# Altium schematic sheet 11_MCU.SchDoc(11_MCU)
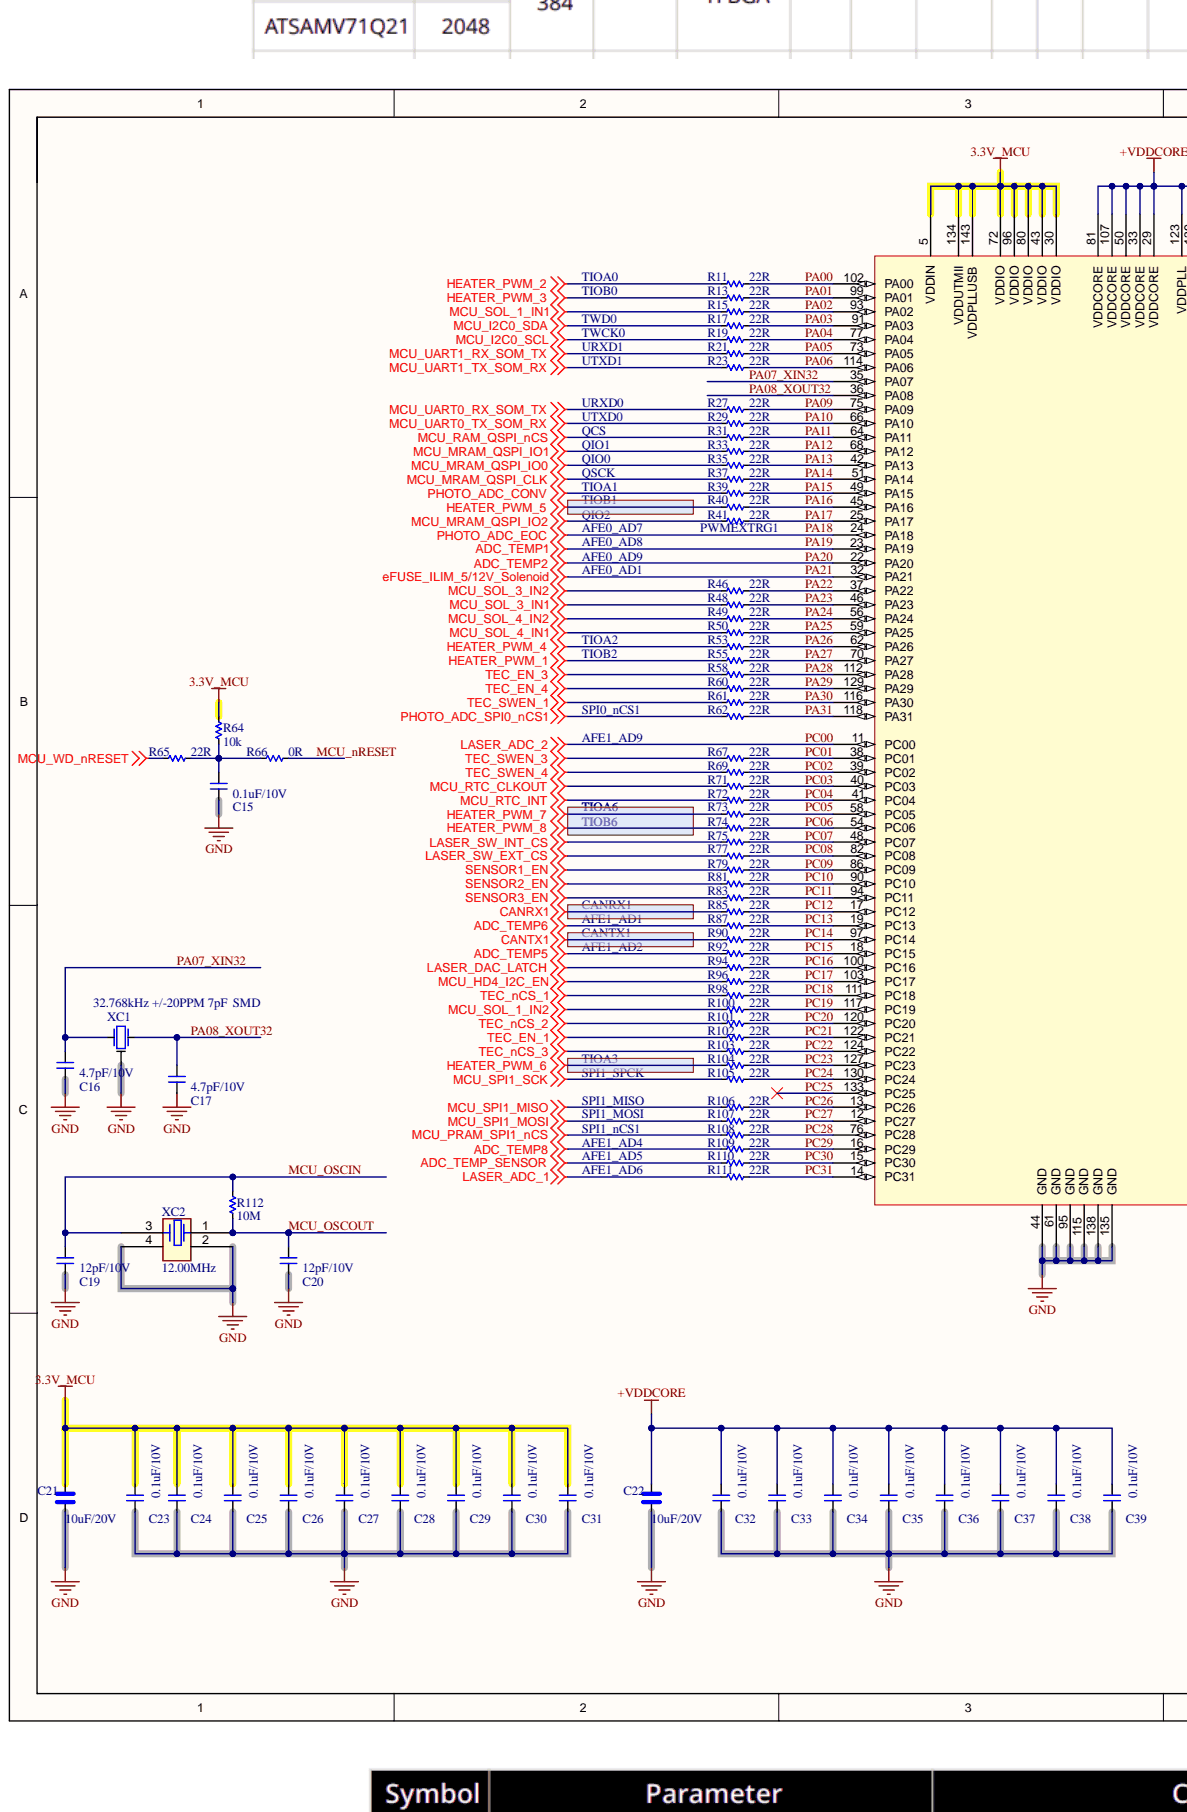
[diagram p0: 11_MCU.SchDoc, left]
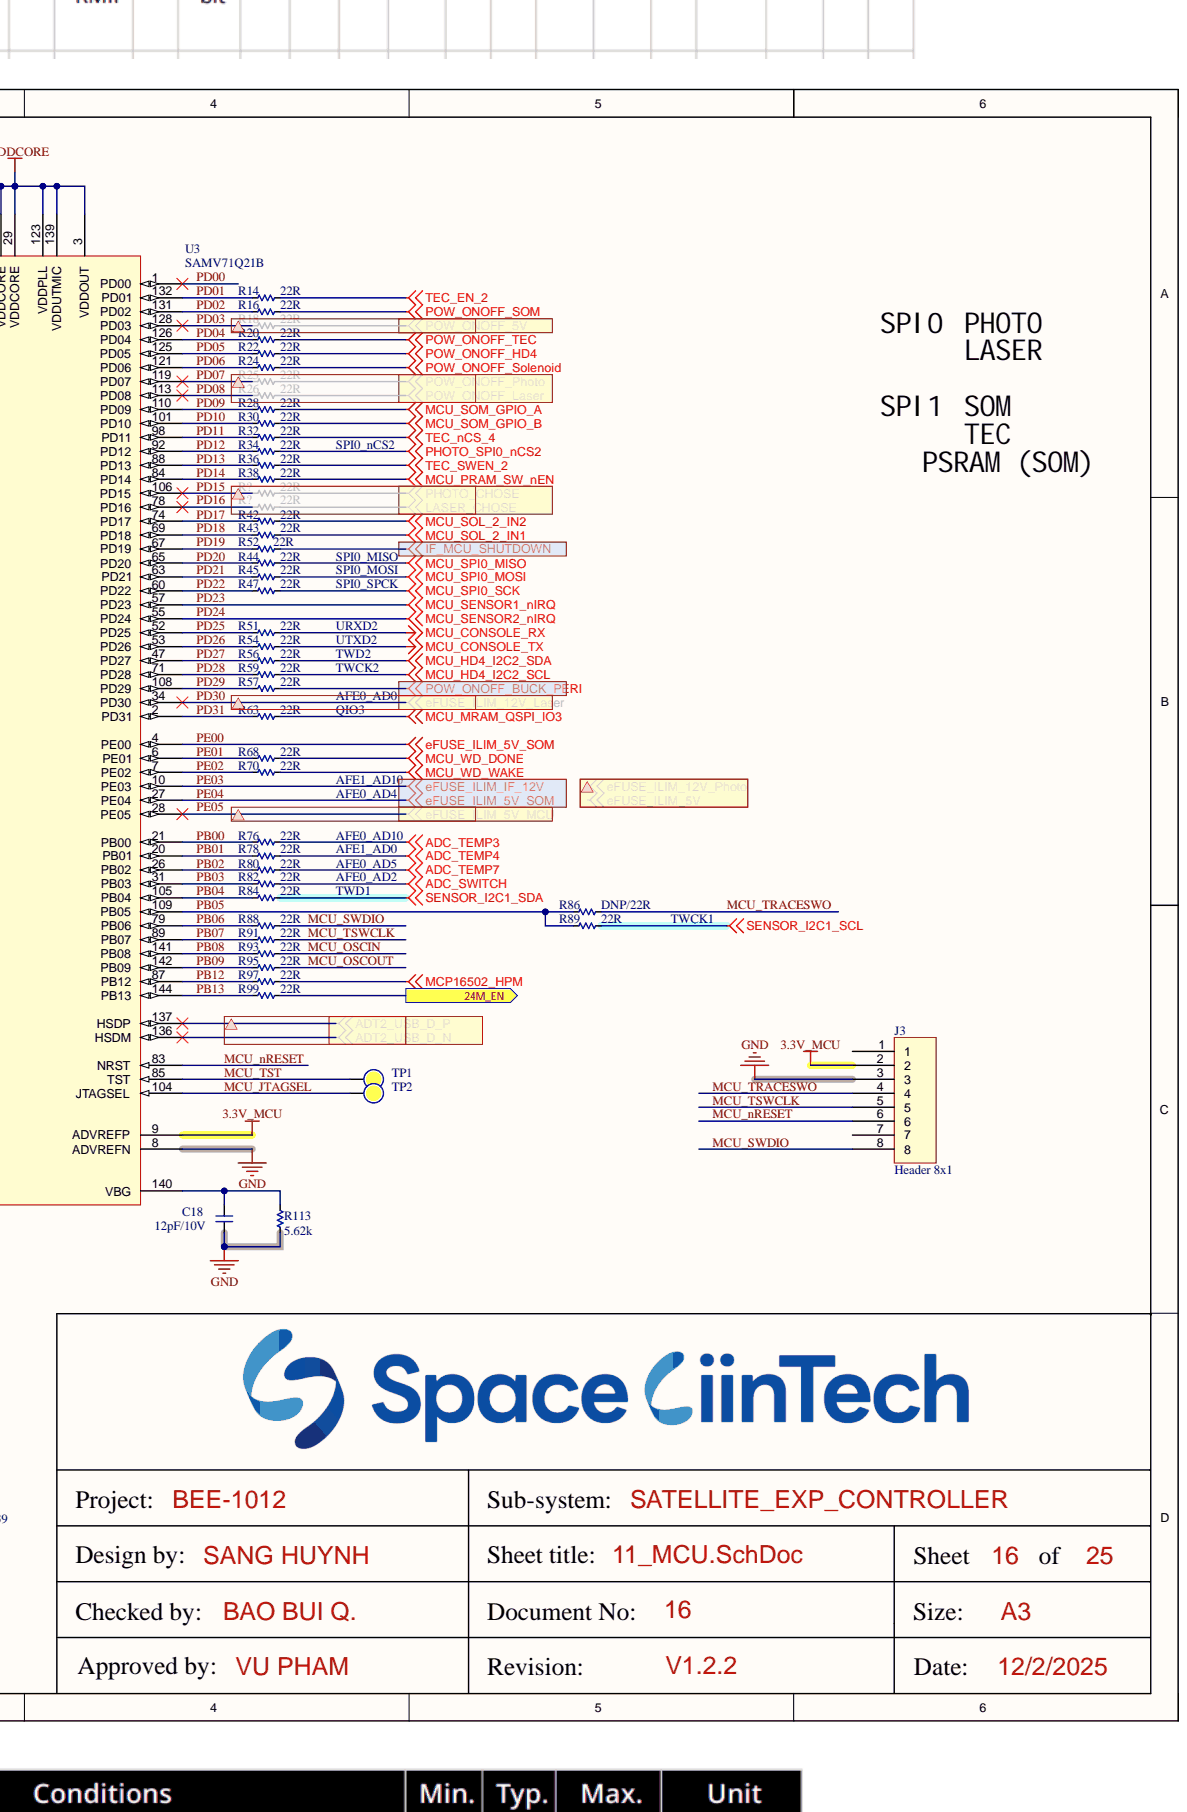
[diagram p1: 11_MCU.SchDoc, right]

## Parts
C15  0.1uF/10V  [CAP_C_1005X60]
C16  4.7pF/10V  [CAP_C_1005X60]
C17  4.7pF/10V  [CAP_C_1005X60]
C18  12pF/10V  [CAP_C_1005X60]
C19  12pF/10V  [CAP_C_1005X60]
C20  12pF/10V  [CAP_C_1005X60]
C21  10uF/20V  [CAP_C_2012X110]
C22  10uF/20V  [CAP_C_2012X110]
C23  0.1uF/10V  [CAP_C_1005X60]
C24  0.1uF/10V  [CAP_C_1005X60]
C25  0.1uF/10V  [CAP_C_1005X60]
C26  0.1uF/10V  [CAP_C_1005X60]
C27  0.1uF/10V  [CAP_C_1005X60]
C28  0.1uF/10V  [CAP_C_1005X60]
C29  0.1uF/10V  [CAP_C_1005X60]
C30  0.1uF/10V  [CAP_C_1005X60]
C31  0.1uF/10V  [CAP_C_1005X60]
C32  0.1uF/10V  [CAP_C_1005X60]
C33  0.1uF/10V  [CAP_C_1005X60]
C34  0.1uF/10V  [CAP_C_1005X60]
C35  0.1uF/10V  [CAP_C_1005X60]
C36  0.1uF/10V  [CAP_C_1005X60]
C37  0.1uF/10V  [CAP_C_1005X60]
C38  0.1uF/10V  [CAP_C_1005X60]
C39  0.1uF/10V  [CAP_C_1005X60]
J3  Header 8x1  [Header_254P_8x1]
R11  22R  [RES_C_1005X40]
R13  22R  [RES_C_1005X40]
R14  22R  [RES_C_1005X40]
R15  22R  [RES_C_1005X40]
R16  22R  [RES_C_1005X40]
R17  22R  [RES_C_1005X40]
R19  22R  [RES_C_1005X40]
R20  22R  [RES_C_1005X40]
R21  22R  [RES_C_1005X40]
R22  22R  [RES_C_1005X40]
R23  22R  [RES_C_1005X40]
R24  22R  [RES_C_1005X40]
R27  22R  [RES_C_1005X40]
R28  22R  [RES_C_1005X40]
R29  22R  [RES_C_1005X40]
R30  22R  [RES_C_1005X40]
R31  22R  [RES_C_1005X40]
R32  22R  [RES_C_1005X40]
R33  22R  [RES_C_1005X40]
R34  22R  [RES_C_1005X40]
R35  22R  [RES_C_1005X40]
R36  22R  [RES_C_1005X40]
R37  22R  [RES_C_1005X40]
R38  22R  [RES_C_1005X40]
R39  22R  [RES_C_1005X40]
R40  22R  [RES_C_1005X40]
R41  22R  [RES_C_1005X40]
R42  22R  [RES_C_1005X40]
R43  22R  [RES_C_1005X40]
R44  22R  [RES_C_1005X40]
R45  22R  [RES_C_1005X40]
R46  22R  [RES_C_1005X40]
R47  22R  [RES_C_1005X40]
R48  22R  [RES_C_1005X40]
R49  22R  [RES_C_1005X40]
R50  22R  [RES_C_1005X40]
R51  22R  [RES_C_1005X40]
R52  22R  [RES_C_1005X40]
R53  22R  [RES_C_1005X40]
R54  22R  [RES_C_1005X40]
R55  22R  [RES_C_1005X40]
R56  22R  [RES_C_1005X40]
R57  22R  [RES_C_1005X40]
R58  22R  [RES_C_1005X40]
R59  22R  [RES_C_1005X40]
R60  22R  [RES_C_1005X40]
R61  22R  [RES_C_1005X40]
R62  22R  [RES_C_1005X40]
R63  22R  [RES_C_1005X40]
R64  10k  [RES_C_1005X40]
R65  22R  [RES_C_1005X40]
R66  0R  [RES_C_1005X40]
R67  22R  [RES_C_1005X40]
R68  22R  [RES_C_1005X40]
R69  22R  [RES_C_1005X40]
R70  22R  [RES_C_1005X40]
R71  22R  [RES_C_1005X40]
R72  22R  [RES_C_1005X40]
R73  22R  [RES_C_1005X40]
R74  22R  [RES_C_1005X40]
R75  22R  [RES_C_1005X40]
R76  22R  [RES_C_1005X40]
R77  22R  [RES_C_1005X40]
R78  22R  [RES_C_1005X40]
R79  22R  [RES_C_1005X40]
R80  22R  [RES_C_1005X40]
R81  22R  [RES_C_1005X40]
R82  22R  [RES_C_1005X40]
R83  22R  [RES_C_1005X40]
R84  22R  [RES_C_1005X40]
R85  22R  [RES_C_1005X40]
R86  DNP/22R  [RES_C_1005X40]
R87  22R  [RES_C_1005X40]
R88  22R  [RES_C_1005X40]
R89  22R  [RES_C_1005X40]
R90  22R  [RES_C_1005X40]
R91  22R  [RES_C_1005X40]
R92  22R  [RES_C_1005X40]
R93  22R  [RES_C_1005X40]
R94  22R  [RES_C_1005X40]
R95  22R  [RES_C_1005X40]
R96  22R  [RES_C_1005X40]
R97  22R  [RES_C_1005X40]
R98  22R  [RES_C_1005X40]
R99  22R  [RES_C_1005X40]
R100  22R  [RES_C_1005X40]
R101  22R  [RES_C_1005X40]
R102  22R  [RES_C_1005X40]
R103  22R  [RES_C_1005X40]
R104  22R  [RES_C_1005X40]
R105  22R  [RES_C_1005X40]
R106  22R  [RES_C_1005X40]
R107  22R  [RES_C_1005X40]
R108  22R  [RES_C_1005X40]
R109  22R  [RES_C_1005X40]
R110  22R  [RES_C_1005X40]
R111  22R  [RES_C_1005X40]
R112  10M  [RES_C_1005X40]
R113  5.62k  [RES_C_1005X40]
TP1  (no value)  [Testpoint_TH_D130H80]  (no BOM)
TP2  (no value)  [Testpoint_TH_D130H80]  (no BOM)
U3  SAMV71Q21B  [AP6-00118]
XC1  value 32.768kHz +/-20PPM 7pF  SMD  [AP7-00044]  (hidden comment: Farnell order no:1539364)
XC2  value 12.00MHz  [AP7-00039]

## Ports
24M_EN

## Nets
3.3V_MCU: C21.1 C23.2 C24.2 C25.2 C26.2 C27.2 C28.2 C29.2 C30.2 C31.2 J3.2 R64.2 U3.5(VDDIN) U3.9(ADVREFP) U3.30(VDDIO) U3.43(VDDIO) U3.72(VDDIO) U3.80(VDDIO) U3.96(VDDIO) U3.134(VDDUTMII) U3.143(VDDPLLUSB)
+VDDCORE: C22.1 C32.2 C33.2 C34.2 C35.2 C36.2 C37.2 C38.2 C39.2 U3.3(VDDOUT) U3.29(VDDCORE) U3.33(VDDCORE) U3.50(VDDCORE) U3.81(VDDCORE) U3.107(VDDCORE) U3.123(VDDPLL) U3.139(VDDUTMIC)
ADC_SWITCH: R82.1
ADC_TEMP3: R76.1
ADC_TEMP4: R78.1
ADC_TEMP5: R92.2
ADC_TEMP6: R87.2
ADC_TEMP7: R80.1
ADC_TEMP8: R109.2
ADC_TEMP_SENSOR: R110.2
CANRX1: R85.2
CANTX1: R90.2
GND: C15.1 C16.1 C17.1 C18.1 C19.1 C20.1 C21.2 C22.2 C23.1 C24.1 C25.1 C26.1 C27.1 C28.1 C29.1 C30.1 C31.1 C32.1 C33.1 C34.1 C35.1 C36.1 C37.1 C38.1 C39.1 J3.3 R113.1 U3.8(ADVREFN) U3.44(GND) U3.61(GND) U3.95(GND) U3.115(GND) U3.135(GND) U3.138(GND) XC1.3 XC2.2 XC2.4
HEATER_PWM_1: R55.2
HEATER_PWM_2: R11.2
HEATER_PWM_3: R13.2
HEATER_PWM_4: R53.2
HEATER_PWM_5: R40.2
HEATER_PWM_6: R104.2
HEATER_PWM_7: R73.2
HEATER_PWM_8: R74.2
IF_MCU_SHUTDOWN: R52.2
LASER_ADC_1: R111.2
LASER_DAC_LATCH: R94.2
LASER_SW_EXT_CS: R77.2
LASER_SW_INT_CS: R75.2
MCP16502_HPM: R97.1
MCU_CONSOLE_RX: R51.1
MCU_CONSOLE_TX: R54.1
MCU_HD4_I2C2_SCL: R59.1
MCU_HD4_I2C2_SDA: R56.1
MCU_HD4_I2C_EN: R96.2
MCU_I2C0_SCL: R19.2
MCU_I2C0_SDA: R17.2
MCU_MRAM_QSPI_CLK: R37.2
MCU_MRAM_QSPI_IO0: R35.2
MCU_MRAM_QSPI_IO1: R33.2
MCU_MRAM_QSPI_IO2: R41.2
MCU_MRAM_QSPI_IO3: R63.1
MCU_PRAM_SPI1_nCS: R108.2
MCU_PRAM_SW_nEN: R38.1
MCU_RAM_QSPI_nCS: R31.2
MCU_RTC_CLKOUT: R71.2
MCU_RTC_INT: R72.2
MCU_SOL_1_IN1: R15.2
MCU_SOL_1_IN2: R100.2
MCU_SOL_2_IN1: R43.1
MCU_SOL_2_IN2: R42.1
MCU_SOL_3_IN1: R48.2
MCU_SOL_3_IN2: R46.2
MCU_SOL_4_IN1: R50.2
MCU_SOL_4_IN2: R49.2
MCU_SOM_GPIO_A: R28.1
MCU_SOM_GPIO_B: R30.1
MCU_SPI0_MISO: R44.1
MCU_SPI0_MOSI: R45.1
MCU_SPI0_SCK: R47.1
MCU_SPI1_MISO: R106.2
MCU_SPI1_MOSI: R107.2
MCU_SPI1_SCK: R105.2
MCU_UART0_RX_SOM_TX: R27.2
MCU_UART0_TX_SOM_RX: R29.2
MCU_UART1_RX_SOM_TX: R21.2
MCU_UART1_TX_SOM_RX: R23.2
MCU_WD_DONE: R68.1
MCU_WD_nRESET: R65.2
MCU_WD_WAKE: R70.1
PHOTO_ADC_CONV: R39.2
PHOTO_ADC_SPI0_nCS1: R62.2
PHOTO_SPI0_nCS2: R34.1
POW_ONOFF_BUCK_PERI: R57.2
POW_ONOFF_HD4: R22.1
POW_ONOFF_Solenoid: R24.1
POW_ONOFF_SOM: R16.1
POW_ONOFF_TEC: R20.1
SENSOR1_EN: R79.2
SENSOR2_EN: R81.2
SENSOR3_EN: R83.2
SENSOR_I2C1_SCL: R89.1
SENSOR_I2C1_SDA: R84.1
TEC_EN_1: R102.2
TEC_EN_2: R14.1
TEC_EN_3: R58.2
TEC_EN_4: R60.2
TEC_nCS_1: R98.2
TEC_nCS_2: R101.2
TEC_nCS_3: R103.2
TEC_nCS_4: R32.1
TEC_SWEN_1: R61.2
TEC_SWEN_2: R36.1
TEC_SWEN_3: R67.2
TEC_SWEN_4: R69.2
24M_EN: R99.1 ; port 24M_EN
MCU_JTAGSEL: TP2.0 U3.104(JTAGSEL)
MCU_nRESET: J3.6 R66.1 U3.83(NRST)
MCU_OSCIN: C19.2 R93.1 R112.2 XC2.3
MCU_OSCOUT: C20.2 R95.1 R112.1 XC2.1
MCU_SWDIO: J3.8 R88.1
MCU_TRACESWO: J3.4 R86.1
MCU_TST: TP1.0 U3.85(TST)
MCU_TSWCLK: J3.5 R91.1
PA00: R11.1 U3.102(PA00)
PA01: R13.1 U3.99(PA01)
PA02: R15.1 U3.93(PA02)
PA03: R17.1 U3.91(PA03)
PA04: R19.1 U3.77(PA04)
PA05: R21.1 U3.73(PA05)
PA06: R23.1 U3.114(PA06)
PA07_XIN32: C16.2 U3.35(PA07) XC1.1
PA08_XOUT32: C17.2 U3.36(PA08) XC1.2
PA09: R27.1 U3.75(PA09)
PA10: R29.1 U3.66(PA10)
PA11: R31.1 U3.64(PA11)
PA12: R33.1 U3.68(PA12)
PA13: R35.1 U3.42(PA13)
PA14: R37.1 U3.51(PA14)
PA15: R39.1 U3.49(PA15)
PA16: R40.1 U3.45(PA16)
PA17: R41.1 U3.25(PA17)
PA18: U3.24(PA18)
PA19: U3.23(PA19)
PA20: U3.22(PA20)
PA21: U3.32(PA21)
PA22: R46.1 U3.37(PA22)
PA23: R48.1 U3.46(PA23)
PA24: R49.1 U3.56(PA24)
PA25: R50.1 U3.59(PA25)
PA26: R53.1 U3.62(PA26)
PA27: R55.1 U3.70(PA27)
PA28: R58.1 U3.112(PA28)
PA29: R60.1 U3.129(PA29)
PA30: R61.1 U3.116(PA30)
PA31: R62.1 U3.118(PA31)
PB00: R76.2 U3.21(PB00)
PB01: R78.2 U3.20(PB01)
PB02: R80.2 U3.26(PB02)
PB03: R82.2 U3.31(PB03)
PB04: R84.2 U3.105(PB04)
PB05: R86.2 R89.2 U3.109(PB05)
PB06: R88.2 U3.79(PB06)
PB07: R91.2 U3.89(PB07)
PB08: R93.2 U3.141(PB08)
PB09: R95.2 U3.142(PB09)
PB12: R97.2 U3.87(PB12)
PB13: R99.2 U3.144(PB13)
PC00: U3.11(PC00)
PC01: R67.1 U3.38(PC01)
PC02: R69.1 U3.39(PC02)
PC03: R71.1 U3.40(PC03)
PC04: R72.1 U3.41(PC04)
PC05: R73.1 U3.58(PC05)
PC06: R74.1 U3.54(PC06)
PC07: R75.1 U3.48(PC07)
PC08: R77.1 U3.82(PC08)
PC09: R79.1 U3.86(PC09)
PC10: R81.1 U3.90(PC10)
PC11: R83.1 U3.94(PC11)
PC12: R85.1 U3.17(PC12)
PC13: R87.1 U3.19(PC13)
PC14: R90.1 U3.97(PC14)
PC15: R92.1 U3.18(PC15)
PC16: R94.1 U3.100(PC16)
PC17: R96.1 U3.103(PC17)
PC18: R98.1 U3.111(PC18)
PC19: R100.1 U3.117(PC19)
PC20: R101.1 U3.120(PC20)
PC21: R102.1 U3.122(PC21)
PC22: R103.1 U3.124(PC22)
PC23: R104.1 U3.127(PC23)
PC24: R105.1 U3.130(PC24)
PC25: U3.133(PC25)
PC26: R106.1 U3.13(PC26)
PC27: R107.1 U3.12(PC27)
PC28: R108.1 U3.76(PC28)
PC29: R109.1 U3.16(PC29)
PC30: R110.1 U3.15(PC30)
PC31: R111.1 U3.14(PC31)
PD00: U3.1(PD00)
PD01: R14.2 U3.132(PD01)
PD02: R16.2 U3.131(PD02)
PD03: U3.128(PD03)
PD04: R20.2 U3.126(PD04)
PD05: R22.2 U3.125(PD05)
PD06: R24.2 U3.121(PD06)
PD07: U3.119(PD07)
PD08: U3.113(PD08)
PD09: R28.2 U3.110(PD09)
PD10: R30.2 U3.101(PD10)
PD11: R32.2 U3.98(PD11)
PD12: R34.2 U3.92(PD12)
PD13: R36.2 U3.88(PD13)
PD14: R38.2 U3.84(PD14)
PD15: U3.106(PD15)
PD16: U3.78(PD16)
PD17: R42.2 U3.74(PD17)
PD18: R43.2 U3.69(PD18)
PD19: R52.1 U3.67(PD19)
PD20: R44.2 U3.65(PD20)
PD21: R45.2 U3.63(PD21)
PD22: R47.2 U3.60(PD22)
PD23: U3.57(PD23)
PD24: U3.55(PD24)
PD25: R51.2 U3.52(PD25)
PD26: R54.2 U3.53(PD26)
PD27: R56.2 U3.47(PD27)
PD28: R59.2 U3.71(PD28)
PD29: R57.1 U3.108(PD29)
PD30: U3.34(PD30)
PD31: R63.2 U3.2(PD31)
PE00: U3.4(PE00) U3.27(PE04)
PE01: R68.2 U3.6(PE01)
PE02: R70.2 U3.7(PE02)
PE03: U3.10(PE03)
PE05: U3.28(PE05)
NetC15_2: C15.2 R64.1 R65.1 R66.2
NetC18_2: C18.2 R113.2 U3.140(VBG)

Not connected: J3 1 7; U3 136(HSDM) 137(HSDP)

## Library data of the parts
C15-C20 C23-C39 = _CAP_C_2: CAP CHIP; Component Kind: Standard; Library Name: LABLIB.SchLib
C21 C22 = _CAP_C_High_2: CAP CHIP High Value; Component Kind: Standard; Library Name: LABLIB.SchLib
R11 R13-R17 R19-R24 R27-R113 = _RES_B_2: RES; Component Kind: Standard; Library Name: LABLIB.SchLib
TP1 TP2 = Test Point: Solder: NF; Description: Test Point; Library Name: LABLIB.SchLib
U3 = A06-1541: SAM 32-bit ARM Cortex-M* RISC MCU, SAMV71B Series, QFP144; Manufacturer: MICROCHIP; MPN: ATSAMV71Q21B-AAB; PartGroup: Integrated Circuits,MCU, MPU, DSP,ARM MCU; Database Table Name: AltiumComponents; TemperatureRange: -40Â°C to +105Â°C; AN: A06-1541; Component Kind: Standard; Height: 1,60000002384186; Library Name: AltiumDbLib.DbLib; Value: SAMV71Q21B
XC1 = A07-0052: 32k768 crystal, +-20ppm, CL=7pF, max ESR 60kOhm, SMD; Manufacturer: Micro Crystal; MPN: MS1V-T1K 32.768kHz 7pF +/-20PPM TA; PartGroup: Frequency devices,Crystals,SMD; Database Table Name: AltiumComponents; TemperatureRange: -40 /+85; Tolerance: +/- 20 PPM; Value: 32.768kHz +/-20PPM 7pF  SMD; AN: A07-0052; Component Kind: Standard; Height: 2,07999992370605; Library Name: AltiumDbLib.DbLib
XC2 = A07-0042: Fox FQ5032B 12.0MHz SMD crystal 738B-12; Manufacturer: Fox  Electronics; MPN: FQ5032B-12.000; PartGroup: Frequency devices,Crystals,SMD; Database Table Name: AltiumComponents; TemperatureRange: 0Â°C ~ 70Â°C; Tolerance: Â±30ppm; AN: A07-0042; Component Kind: Standard; Height: 1,20000004768372; Library Name: AltiumDbLib.DbLib
J3 = Header 8x1: Component Kind: Standard; Library Name: LABLIB.SchLib
